# Altium schematic sheet sensors.SchDoc(sensors)
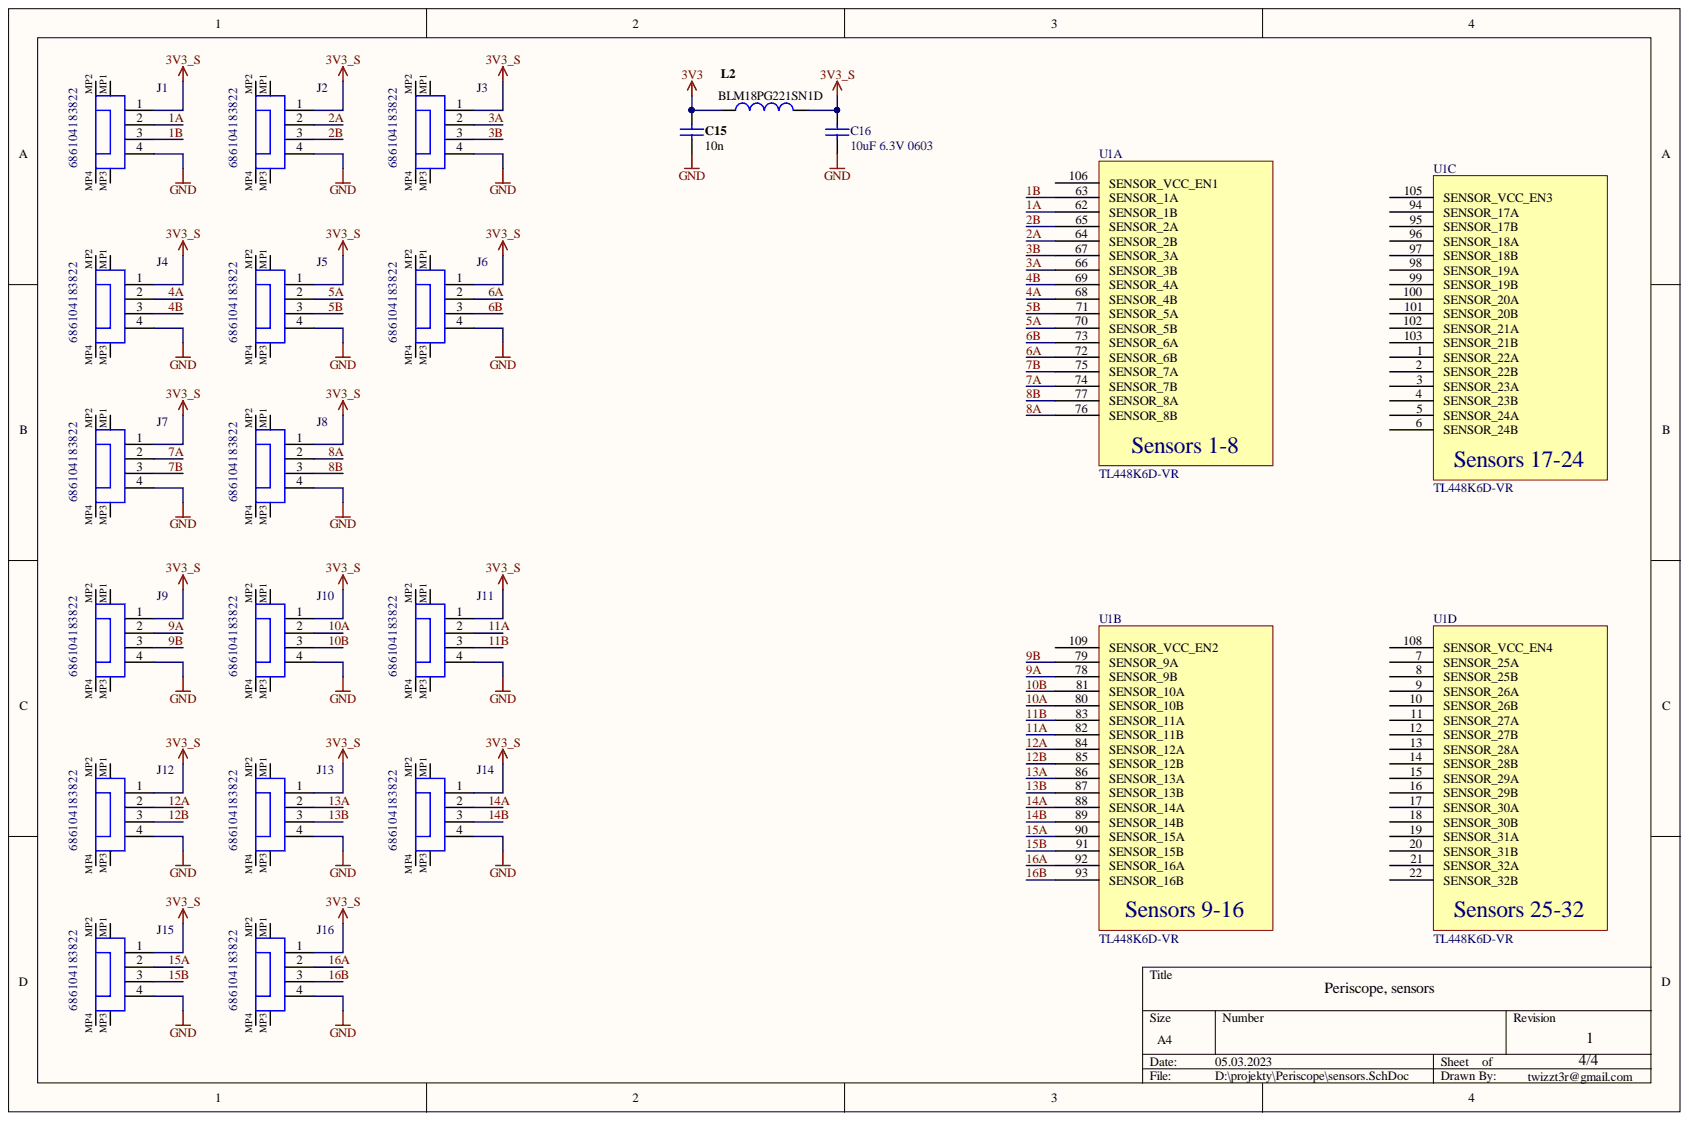
[diagram p0: sensors.SchDoc]

## Parts
C15  value 10n  [C_0603]  (hidden comment: 10n 50v 0603)  (net tie)
C16  10uF 6.3V 0603  Capacitance: 10uF  [WCAP-CSGP_0603_H=0.8mm_Reflow]
J1  686104183822  Rated Current: 1A  [686104183822]
J2  686104183822  [686104183822]
J3  686104183822  [686104183822]
J4  686104183822  [686104183822]
J5  686104183822  [686104183822]
J6  686104183822  [686104183822]
J7  686104183822  [686104183822]
J8  686104183822  [686104183822]
J9  686104183822  [686104183822]
J10  686104183822  [686104183822]
J11  686104183822  [686104183822]
J12  686104183822  [686104183822]
J13  686104183822  [686104183822]
J14  686104183822  [686104183822]
J15  686104183822  [686104183822]
J16  686104183822  [686104183822]
L2  BLM18PG221SN1D  [R_0805]
U1  TL448K6D-VR  [TL448K6D-VR]  (parts A,B,C,D)

## Nets
3V3: C15.2 L2.2
3V3_S: C16.2 J1.1 J2.1 J3.1 J4.1 J5.1 J6.1 J7.1 J8.1 J9.1 J10.1 J11.1 J12.1 J13.1 J14.1 J15.1 J16.1 L2.1
GND: C15.1 C16.1 J1.4 J2.4 J3.4 J4.4 J5.4 J6.4 J7.4 J8.4 J9.4 J10.4 J11.4 J12.4 J13.4 J14.4 J15.4 J16.4
1A: J1.2 U1.62(SENSOR_1B)
1B: J1.3 U1.63(SENSOR_1A)
2A: J2.2 U1.64(SENSOR_2B)
2B: J2.3 U1.65(SENSOR_2A)
3A: J3.2 U1.66(SENSOR_3B)
3B: J3.3 U1.67(SENSOR_3A)
4A: J4.2 U1.68(SENSOR_4B)
4B: J4.3 U1.69(SENSOR_4A)
5A: J5.2 U1.70(SENSOR_5B)
5B: J5.3 U1.71(SENSOR_5A)
6A: J6.2 U1.72(SENSOR_6B)
6B: J6.3 U1.73(SENSOR_6A)
7A: J7.2 U1.74(SENSOR_7B)
7B: J7.3 U1.75(SENSOR_7A)
8A: J8.2 U1.76(SENSOR_8B)
8B: J8.3 U1.77(SENSOR_8A)
9A: J9.2 U1.78(SENSOR_9B)
9B: J9.3 U1.79(SENSOR_9A)
10A: J10.2 U1.80(SENSOR_10B)
10B: J10.3 U1.81(SENSOR_10A)
11A: J11.2 U1.82(SENSOR_11B)
11B: J11.3 U1.83(SENSOR_11A)
12A: J12.2 U1.84(SENSOR_12A)
12B: J12.3 U1.85(SENSOR_12B)
13A: J13.2 U1.86(SENSOR_13A)
13B: J13.3 U1.87(SENSOR_13B)
14A: J14.2 U1.88(SENSOR_14A)
14B: J14.3 U1.89(SENSOR_14B)
15A: J15.2 U1.90(SENSOR_15A)
15B: J15.3 U1.91(SENSOR_15B)
16A: J16.2 U1.92(SENSOR_16A)
16B: J16.3 U1.93(SENSOR_16B)

Not connected: J1 MP1 MP2 MP3 MP4; J2 MP1 MP2 MP3 MP4; J3 MP1 MP2 MP3 MP4; J4 MP1 MP2 MP3 MP4; J5 MP1 MP2 MP3 MP4; J6 MP1 MP2 MP3 MP4; J7 MP1 MP2 MP3 MP4; J8 MP1 MP2 MP3 MP4; J9 MP1 MP2 MP3 MP4; J10 MP1 MP2 MP3 MP4; J11 MP1 MP2 MP3 MP4; J12 MP1 MP2 MP3 MP4; J13 MP1 MP2 MP3 MP4; J14 MP1 MP2 MP3 MP4; J15 MP1 MP2 MP3 MP4; J16 MP1 MP2 MP3 MP4; U1 1(SENSOR_22A) 2(SENSOR_22B) 3(SENSOR_23A) 4(SENSOR_23B) 5(SENSOR_24A) 6(SENSOR_24B) 7(SENSOR_25A) 8(SENSOR_25B) 9(SENSOR_26A) 10(SENSOR_26B) 11(SENSOR_27A) 12(SENSOR_27B) 13(SENSOR_28A) 14(SENSOR_28B) 15(SENSOR_29A) 16(SENSOR_29B) 17(SENSOR_30A) 18(SENSOR_30B) 19(SENSOR_31A) 20(SENSOR_31B) 21(SENSOR_32A) 22(SENSOR_32B) 94(SENSOR_17A) 95(SENSOR_17B) 96(SENSOR_18A) 97(SENSOR_18B) 98(SENSOR_19A) 99(SENSOR_19B) 100(SENSOR_20A) 101(SENSOR_20B) 102(SENSOR_21A) 103(SENSOR_21B) 105(SENSOR_VCC_EN3) 106(SENSOR_VCC_EN1) 108(SENSOR_VCC_EN4) 109(SENSOR_VCC_EN2)

## Library data of the parts
C15 = C_10n_50v_0603: mfg_pn: CL10B103KB8NNNC; Rated Voltage: 50v; Library Name: SCH.SchLib; Description: 10n 50V 0603
C16 = C_10u_6V3_0603: WCAP-CSGP Multilayer Ceramic Chip Capacitor, General Purpose, size 0603, X5R, 10uF, 6.3V; Manufacturer Part Number: 885012106006; Manufacturer: Wurth Elektronik; Technical Reference: X5R0603106M6R3DFCT10000; Rated Voltage: 6.3VDC; Isolation Resistance  min.: 0.01GOhm; Dissipation Factor max.: 10%; Capacitance Tolerance: +/-20%; Size: 0603; Q-Factor min.: -; Published Date: April 2021; Packaging: Tape and Reel; Operating Temperature: -55 to +85degC; Mounting Technology: SMT; Library Name: SCH.SchLib; Height: 0.8mm; ComponentLink2URL: https://www.we-online.com/catalog/datasheet/885012106006.pdf; ComponentLink2Description: Datasheet; ComponentLink1URL: http://www.we-online.de; ComponentLink1Description: Manufacturer; Component Kind: Standard; Ceramic Type: X5R
J1 = 686104183822: WR-FPC SMT ZIF Vertical Type A, pitch 1mm, 4p; Manufacturer: Wurth Elektronik; Working Voltage: 125VAC; Pin Number: 4; Contact Resistance: 30mOhm; Type: Vertical; Published Date: March 2021; Pitch: 1mm; Packaging: Tape and Reel; Operating Temperature: -25 to +85degC; Mounting Technology: SMT; Manufacturer Part Number: 686104183822; Library Name: SCH.SchLib; Length: 10.8mm; ComponentLink2URL: https://katalog.we-online.com/em/datasheet/686104183822.pdf; ComponentLink2Description: Datasheet; ComponentLink1URL: http://www.we-online.de; ComponentLink1Description: Manufacturer; Component Kind: Standard
J2-J16 = 686104183822: WR-FPC SMT ZIF Vertical Type A, pitch 1mm, 4p; Manufacturer: Wurth Elektronik; Working Voltage: 125VAC; Rated Current: 1A; Pin Number: 4; Contact Resistance: 30mOhm; Type: Vertical; Published Date: March 2021; Pitch: 1mm; Packaging: Tape and Reel; Operating Temperature: -25 to +85degC; Mounting Technology: SMT; Manufacturer Part Number: 686104183822; Library Name: SCH.SchLib; Length: 10.8mm; ComponentLink2URL: https://katalog.we-online.com/em/datasheet/686104183822.pdf; ComponentLink2Description: Datasheet; ComponentLink1URL: http://www.we-online.de; ComponentLink1Description: Manufacturer; Component Kind: Standard
L2 = L_0603: SMD-Multilayer Inductor; PackageVersion: 2009; PackageReference: SMD-0603; Library Name: SCH.SchLib; Component Kind: Standard
U1 = TL448K6D-VR: Library Name: SCH.SchLib; Component Kind: Standard
